# Altium schematic sheet FFD.SchDoc(FFD)
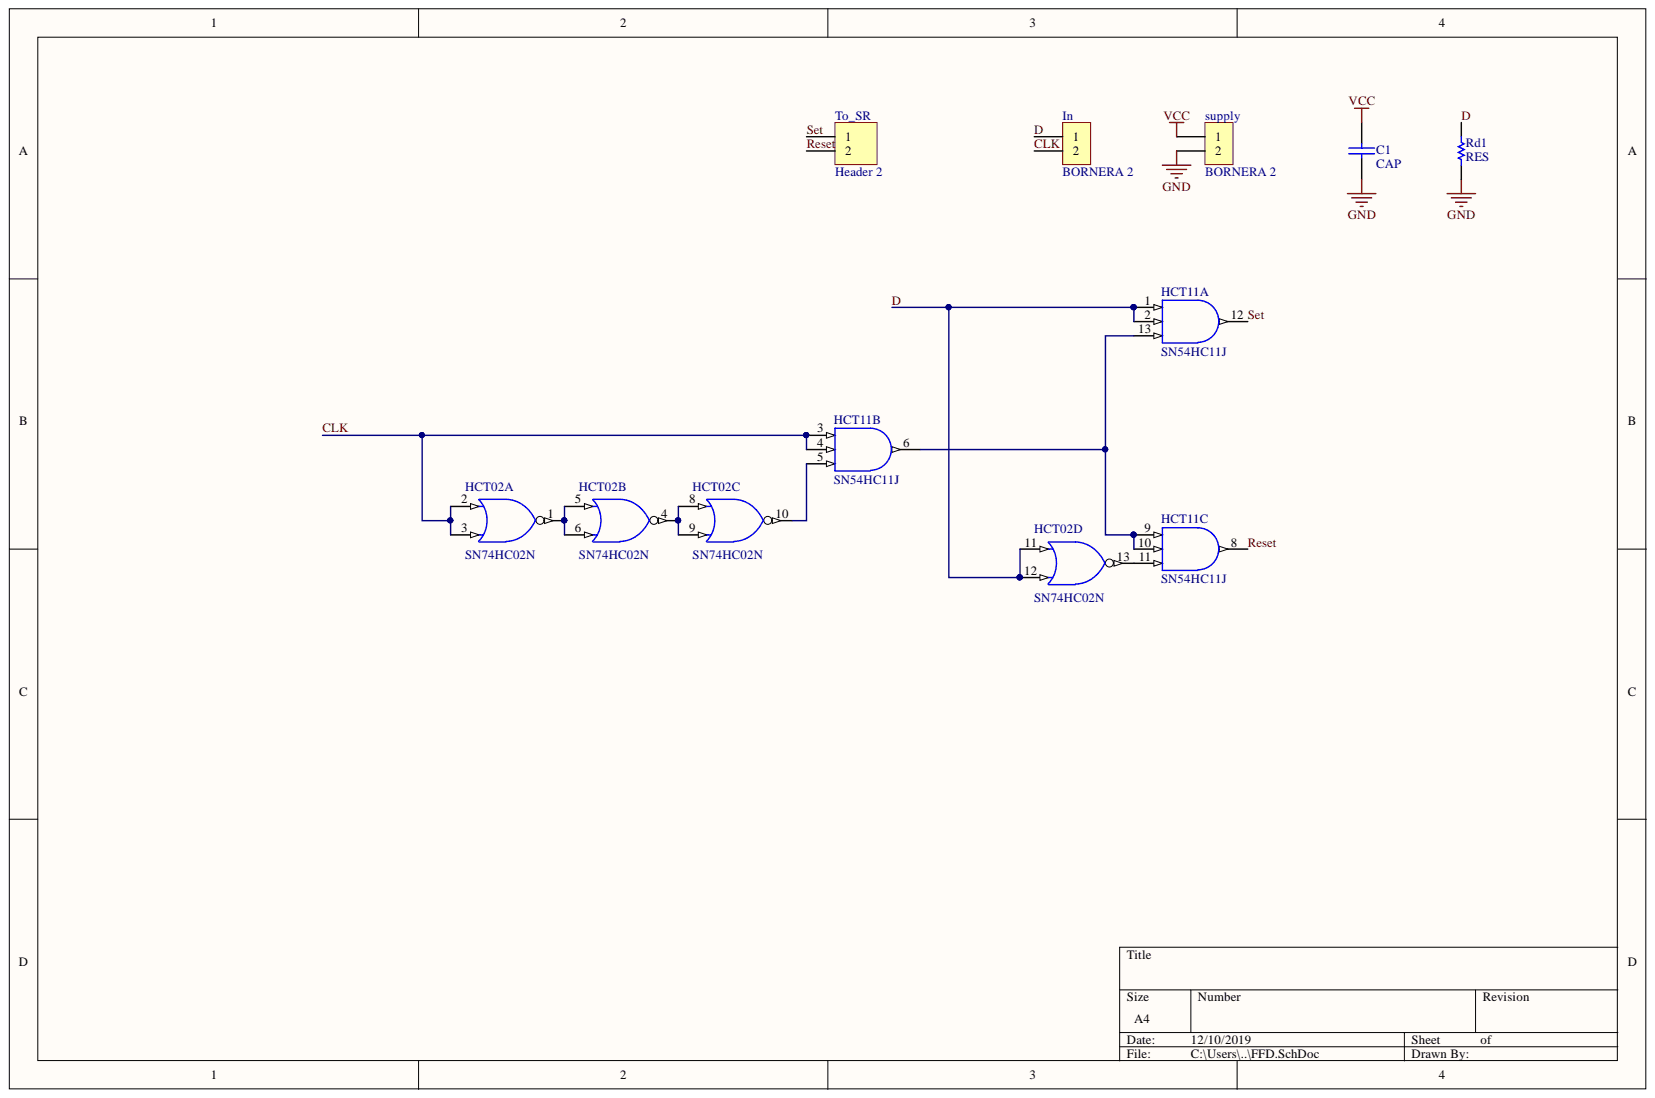
[diagram p0: FFD.SchDoc]

## Parts
C1  CAP  [CAP100]
HCT02  SN74HC02N  [N014]  (parts A,B,C,D)
HCT11  SN54HC11J  [J014]  (parts A,B,C)
In  BORNERA 2  [BORNERA2]
Rd1  RES  [0805]
supply  BORNERA 2  [BORNERA2]
To_SR  Header 2  [HDR1X2]

## Nets
GND: C1.2 HCT02.7 HCT11.7 Rd1.1 supply.2
VCC: C1.1 HCT02.14 HCT11.14 supply.1
CLK: HCT02.2 HCT02.3 HCT11.3 HCT11.4 In.2
D: HCT02.11 HCT02.12 HCT11.1 HCT11.2 In.1 Rd1.2
Reset: HCT11.8 To_SR.2
Set: HCT11.12 To_SR.1
NetHCT02_1: HCT02.1 HCT02.5 HCT02.6
NetHCT02_4: HCT02.4 HCT02.8 HCT02.9
NetHCT02_10: HCT02.10 HCT11.5
NetHCT02_13: HCT02.13 HCT11.11
NetHCT11_6: HCT11.6 HCT11.9 HCT11.10 HCT11.13

## Library data of the parts
HCT02 = SN74HC02N: Quadruple 2-Input Positive-NOR Gate; Signal Integrity: SN74HC02N; Published: 8-Jun-2000; PCB3D: N014; PackageVersion: Oct-1995; PackageReference: N014; PackageDescription: DIP; 14 Leads; Row Spacing 7.62 mm; Pitch 2.54 mm; Library Name: TI Logic Gate 2.IntLib; LatestRevisionNote: Stylized 3D Model Added.; LatestRevisionDate: 04-Mar-2005; DatasheetVersion: May-1997; ComponentLink2URL: http://www-s.ti.com/sc/ds/sn74hc02.pdf; ComponentLink2Description: Datasheet; ComponentLink1URL: http://www.ti.com/; ComponentLink1Description: Manufacturer Link; Component Kind: Standard; Publisher: Altium Limited
HCT11 = SN54HC11J: Triple 3-Input Positive-AND Gate; Signal Integrity: SN54HC11J; Published: 8-Jun-2000; PCB3D: J014; PackageVersion: Jun-1999; PackageReference: J014; PackageDescription: DIP; 14 Leads; Row Spacing 7.62 mm; Pitch 2.54 mm; Library Name: TI Logic Gate 1.IntLib; LatestRevisionNote: Stylized 3D Model Added.; LatestRevisionDate: 04-Mar-2005; DatasheetVersion: May-1997; ComponentLink3URL: http://focus.ti.com/lit/ml/mcer002d/mcer002d.pdf; ComponentLink3Description: Packaging Specification; ComponentLink2URL: http://www-s.ti.com/sc/ds/sn54hc11.pdf; ComponentLink2Description: Datasheet; ComponentLink1URL: http://www.ti.com/; ComponentLink1Description: Manufacturer Link; Component Kind: Standard; Publisher: Altium Limited
To_SR = Header 2: Header, 2-Pin; Signal Integrity: Connector; Library Name: Miscellaneous Connectors.IntLib; LatestRevisionNote: Re-released for DXP Platform.; LatestRevisionDate: 17-Jul-2002; Component Kind: Standard; Publisher: Altium Limited
C1 = CAP: Library Name: LIBEBAL.IntLib; Component Kind: Standard
In supply = BORNERA 2: Library Name: LIBEBAL.IntLib; Component Kind: Standard
Rd1 = RES: Library Name: LIBEBAL.IntLib; Component Kind: Standard
